# Altium schematic sheet MCU-ADDON.SchDoc
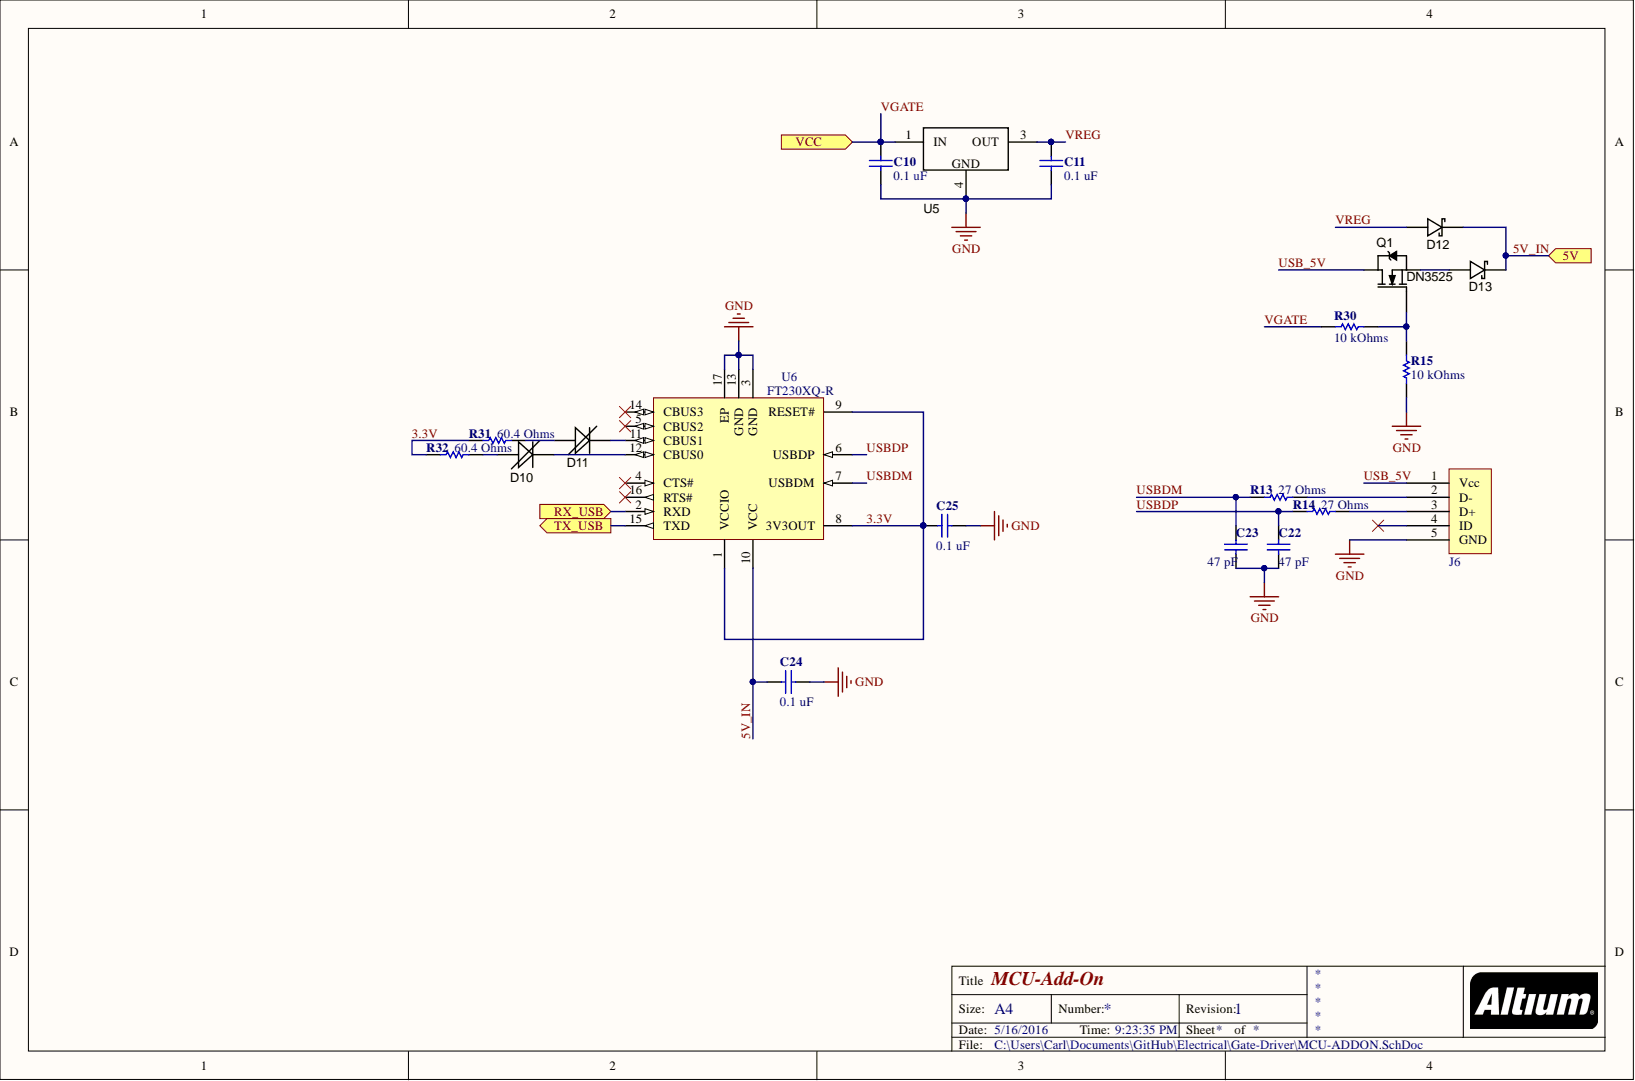
[diagram p0: MCU-ADDON.SchDoc]

## Parts
C10  0.1 uF  [CAP-0402(1005)-R]
C11  0.1 uF  [CAP-0402(1005)-R]
C22  47 pF  [CAP-0603(1608)-R]
C23  47 pF  [CAP-0603(1608)-R]
C24  0.1 uF  [CAP-0402(1005)-R]
C25  0.1 uF  [CAP-0402(1005)-R]
D10  Red  [LED-1206]  (value not drawn)
D11  Red  [LED-1206]  (value not drawn)
D12  MBR120VLSFT3G  [SOD-123FL]  (value not drawn)
D13  MBR120VLSFT3G  [SOD-123FL]  (value not drawn)
J6  USB  [USB_Connector_5P_MICRO_USB_FCI]
Q1  DN3525  [SOT89-3]
R13  27 Ohms  [R-0603(1608)-R]
R14  27 Ohms  [R-0603(1608)-R]
R15  10 kOhms  [R-0603(1608)-R]
R30  10 kOhms  [R-0603(1608)-R]
R31  60.4 Ohms  [R-0603(1608)-R]
R32  60.4 Ohms  [R-0603(1608)-R]
U5  FIXED 5V  [TO-252]  (value not drawn)
U6  FT230XQ-R  [QFN-16]

## Ports
5V, RX_USB, TX_USB, VCC

## Nets
GND: C10.2 C11.2 C22.2 C23.2 C24.2 C25.2 J6.5(GND) R15.1 U5.4 U6.3(GND) U6.13(GND) U6.17(EP)
3.3V: C25.1 R31.1 R32.1 U6.1(VCCIO) U6.8(3V3OUT) U6.9(RESET#)
5V_IN: C24.1 D12.K D13.K U6.10(VCC) ; port 5V
RX_USB: U6.2(RXD) ; port RX_USB
TX_USB: U6.15(TXD) ; port TX_USB
USB_5V: J6.1(Vcc) Q1.2
USBDM: C23.1 R13.1 U6.7(USBDM)
USBDP: C22.1 R14.1 U6.6(USBDP)
VGATE: C10.1 R30.1 U5.1 ; port VCC
VREG: C11.1 D12.A U5.3
NetD10_A: D10.A R32.2
NetD10_K: D10.K U6.12(CBUS0)
NetD11_A: D11.A R31.2
NetD11_K: D11.K U6.11(CBUS1)
NetD13_A: D13.A Q1.3
NetJ6_2: J6.2(D-) R13.2
NetJ6_3: J6.3(D+) R14.2
NetQ1_1: Q1.1 R15.2 R30.2

Not connected: J6 4(ID); U6 4(CTS#) 5(CBUS2) 14(CBUS3) 16(RTS#)

## Library data of the parts
C10 C11 C24 C25 = CAP- 0.1 uF- 16 V-CER-0402(1005): CAP CER 0.1 UF 16V 5% X7R 0402; Manufacturer Part Number: CC0402KRX7R7BB104; Brand: Yageo; Tolerance: 10 %; Type: Multilayer Ceramic Chip Capacitor; Voltage Rating DC: 16 VDC; Capacitance: 0.1 uF; Case Code - in: 0402; Case Code - mm: 1005; Category: Multilayer Ceramic Capacitors MLCC - SMD/SMT; Component Kind: Standard; ComponentLink1Description: http://www.mouser.com/ds/2/447/UPY-GPHC_X7R_6.3V-to-50V_8-12304.pdf; ComponentLink1URL: http://www.mouser.com/ds/2/447/UPY-GPHC_X7R_6.3V-to-50V_8-12304.pdf; Dielectric: X7R; Manufacturer: Yageo; Maximum Operating Temperature: + 125 C; Minimum Operating Temperature: - 55 C; Minimum Order Quantity: 1; Package / Case: 0402 (1005 metric); Packaging: Reel; Pricing 1: 1=0.100, 10=0.009, 100=0.004, 1000=0.003, 2500=0.002, 10000=0.002 (USD); Product: General Type MLCCs; Reeling: True; RoHS: RoHS Compliant By Exemption; RoHS - Mouser: E; Standard Pack Qty: 10000; Stock 1: 655262; Supplier 1: Mouser; Supplier Part Number 1: 603-CC402KRX7R7BB104; Termination Style: SMD/SMT; Library Name: CarlLibrary.SchLib
C22 C23 = CAP- 47 pF- 50 V-CER-0603(1608): CAP CER 47 pF 50V 5% C0G 0603; Manufacturer Part Number: CC0603JRNPO9BN470; Brand: Yageo; Dielectric: C0G (NP0); Type: Multilayer Ceramic Chip Capacitor; Voltage Rating DC: 50 VDC; Capacitance: 47 pF; Case Code - in: 0603; Case Code - mm: 1608; Category: Multilayer Ceramic Capacitors MLCC - SMD/SMT; Component Kind: Standard; ComponentLink1Description: http://www.mouser.com/ds/2/447/UPY-GP_NP0_16V-to-50V_8-3714.pdf; ComponentLink1URL: http://www.mouser.com/ds/2/447/UPY-GP_NP0_16V-to-50V_8-3714.pdf; Length: 1.6 mm; Manufacturer: Yageo; Maximum Operating Temperature: + 125 C; Minimum Operating Temperature: - 55 C; Minimum Order Quantity: 1; Package / Case: 0603 (1608 metric); Packaging: Reel; Pricing 1: 1=0.100, 10=0.021, 100=0.009, 1000=0.007, 4000=0.005, 48000=0.004, 100000=0.003 (USD); Product: General Type MLCCs; Reeling: True; RoHS: RoHS Compliant; RoHS - Mouser: E; Standard Pack Qty: 4000; Stock 1: 0; Supplier 1: Mouser; Supplier Part Number 1: 603-CC603JRNPO9BN470; Termination Style: SMD/SMT; Tolerance: 5 %; Width: 0.8 mm; Library Name: CarlLibrary.SchLib
D10 D11 = LED_SMD_RED_0805: LED, 0805 SMD, Red; Manufacturer Part Number: APTD3216SURCK; Brand: Kingbright; If - Forward Current: 20 mA; LED Size: 3.2 mm x 1.6 mm; Lens Color/Style: Water Clear; Luminous Intensity: 1000 mcd; Vf - Forward Voltage: 1.95 V; Viewing Angle: 50 deg; Wavelength/Color Temperature: 630 nm; Category: Standard LEDs - SMD; Component Kind: Standard; ComponentLink1Description: http://www.mouser.com/ds/2/216/APTD3216SURCK-65552.pdf; ComponentLink1URL: http://www.mouser.com/ds/2/216/APTD3216SURCK-65552.pdf; Illumination Color: Red; Manufacturer: Kingbright; Maximum Operating Temperature: + 85 C; Minimum Operating Temperature: - 40 C; Minimum Order Quantity: 1; Mounting Style: SMD/SMT; Package / Case: 1206; Packaging: Reel; Pricing 1: 1=0.270, 10=0.169, 100=0.125, 1000=0.103, 2000=0.092, 10000=0.084, 24000=0.075, 50000=0.071, 100000=0.068 (USD); Product Category: LED SMD Super Bright; Reeling: True; RoHS: RoHS Compliant; RoHS - Mouser: Y; Standard Pack Qty: 2000; Stock 1: 52291; Supplier 1: Mouser; Supplier Part Number 1: 604-APTD-3216SURCK; Library Name: CarlLibrary.SchLib
D12 D13 = DIODE_SCHOTTKY: Diode Schottky SMD 20V 1A SMD; Brand: ON Semiconductor; Configuration: Single; Ifsm - Forward Surge Current: 45 A; Ir - Reverse Current: 600 uA; Vf - Forward Voltage: 0.34 V; Category: Schottky Diodes & Rectifiers; Component Kind: Standard; ComponentLink1Description: http://www.mouser.com/ds/2/308/MBR120VLSFT1-D-107081.pdf; ComponentLink1URL: http://www.mouser.com/ds/2/308/MBR120VLSFT1-D-107081.pdf; If - Forward Current: 1 A; Manufacturer: ON Semiconductor; Manufacturer Part Number: MBR120VLSFT3G; Maximum Operating Temperature: + 125 C; Minimum Operating Temperature: - 65 C; Minimum Order Quantity: 1; Mounting Style: SMD/SMT; Package / Case: SOD-123FL; Packaging: Reel; Pricing 1: 1=0.360, 10=0.279, 100=0.151, 1000=0.114, 2500=0.098, 10000=0.091, 20000=0.084, 50000=0.081, 100000=0.076 (USD); Product: Schottky Diodes; Product Category: Diodes- Schottky; Reeling: True; RoHS: RoHS Compliant; RoHS - Mouser: Y; Series: MBR120VLSF; Standard Pack Qty: 10000; Stock 1: 22244; Supplier 1: Mouser; Supplier Part Number 1: 863-MBR120VLSFT3G; Technology: Si; Vrrm - Repetitive Reverse Voltage: 20 V; Library Name: CarlLibrary.SchLib
J6 = USB: USB_Connector_5P_MICRO_USB_FCI; Manufacturer Part Number: 10118194-0001LF; Brand: FCI; Connector Type: Micro USB Type B receptacle; Current Rating: 1.8 A; Gender: Female; Insulation Resistance: 1000 MOhms; Mounting Angle: Right; Number of Contacts: 5 Contact; Category: USB Connectors; Color: Black; Component Kind: Standard; ComponentLink1Description: http://www.mouser.com/ds/2/154/10118194AC-197217.pdf; ComponentLink1URL: http://www.mouser.com/ds/2/154/10118194AC-197217.pdf; Contact Material: Copper Alloy; Contact Plating: Gold; Flammability Rating: UL 94 V-0; Library Name: MasterLibrary.SchLib; Manufacturer: FCI; Minimum Order Quantity: 1; Operating Temperature Range: - 55 C to + 85 C; Packaging: Reel; Pricing 1: 1=0.410, 10=0.396, 100=0.278, 500=0.238, 1200=0.198, 2400=0.181, 4800=0.170, 9600=0.159, 24000=0.153 (USD); Product: Micro USB Type B Connectors; Reeling: True; RoHS: RoHS Compliant; RoHS - Mouser: Y; Standard: USB 2.0; Standard Pack Qty: 9600; Stock 1: 17001; Supplier 1: Mouser; Supplier Part Number 1: 649-10118194-0001LF; Termination Style: SMD/SMT
Q1 = DN3525N8-G: MOSFET N-Channel DEPLETION SMD; Brand: Microchip Technology; Configuration: Single; Fall Time: 25 ns; Id - Continuous Drain Current: 360 mA; Number of Channels: 1 Channel; Pd - Power Dissipation: 1.6 W; Rds On - Drain-Source Resistance: 6 Ohms; Transistor Type: 1 N-Channel; Typical Turn-On Delay Time: 20 ns; Vds - Drain-Source Breakdown Voltage: 250 V; Vgs - Gate-Source Voltage: 20 V; Category: MOSFET; Channel Mode: Depletion; Component Kind: Standard; Manufacturer: Microchip Technology; Manufacturer Part Number: DN3525N8-G; Maximum Operating Temperature: + 150 C; Minimum Operating Temperature: - 55 C; Minimum Order Quantity: 1; Mounting Style: SMD/SMT; Package / Case: SOT-89-3; Packaging: Reel; Pricing 1: 1=0.720, 10=0.650, 25=0.540, 100=0.490, 2000=0.490 (USD); Product Category: MOSFETs - Depletion Mode N-Channel; Reeling: True; Rise Time: 25 ns; RoHS: RoHS Compliant; RoHS - Mouser: Y; Standard Pack Qty: 2000; Stock 1: 34759; Supplier 1: Mouser; Supplier Part Number 1: 689-DN3525N8-G; Transistor Polarity: N-Channel; Typical Turn-Off Delay Time: 25 ns; Library Name: CarlLibrary.SchLib
R13 R14 = R-27-100 mW-0603: RES 27 OHM 100 mW  SMD; Manufacturer Part Number: RC0603JR-0727RL; Brand: Yageo; Power Rating: 100 mW; Temperature Coefficient: 100 PPM / C; Tolerance: 5 %; Voltage Rating: 75 V; Case Code - in: 0603; Case Code - mm: 1608; Category: Thick Film Resistors - SMD; Component Kind: Standard; ComponentLink1Description: http://www.mouser.com/ds/2/447/RC0603-272842.pdf; ComponentLink1URL: http://www.mouser.com/ds/2/447/RC0603-272842.pdf; Height: 0.45 mm; Length: 1.6 mm; Manufacturer: Yageo; Minimum Order Quantity: 1; Operating Temperature Range: - 55 C to + 155 C; Package / Case: 0603 (1608 metric); Packaging: Reel; Pricing 1: 1=0.100, 10=0.008, 100=0.003, 1000=0.002, 5000=0.002, 50000=0.001 (USD); Product: Thick Film Resistors General Purpose; Product Category: 0603 SMD Chip Resistors; Reeling: True; Resistance: 27 Ohms; RoHS: RoHS Compliant; RoHS - Mouser: Y; Series: RC; Standard Pack Qty: 5000; Stock 1: 21649; Supplier 1: Mouser; Supplier Part Number 1: 603-RC0603JR-0727RL; Termination Style: SMD/SMT; Type: General Purpose Chip Resistor Surface Mount; Width: 0.8 mm; Library Name: CarlLibrary.SchLib
R15 R30 = R-10k-100mW-0603: RES 10k OHM 1/10W 0603 SMD; Manufacturer Part Number: RC0603FR-0710KL; Brand: Yageo; Power Rating: 100 mW; Temperature Coefficient: 100 PPM / C; Tolerance: 1 %; Voltage Rating: 75 V; Case Code - in: 0603; Case Code - mm: 1608; Category: Thick Film Resistors - SMD; Component Kind: Standard; ComponentLink1Description: http://www.mouser.com/ds/2/447/RC0603-272842.pdf; ComponentLink1URL: http://www.mouser.com/ds/2/447/RC0603-272842.pdf; Height: 0.45 mm; Length: 1.6 mm; Manufacturer: Yageo; Minimum Order Quantity: 1; Operating Temperature Range: - 55 C to + 155 C; Package / Case: 0603 (1608 metric); Packaging: Reel; Pricing 1: 1=0.100, 10=0.010, 100=0.003, 1000=0.002, 5000=0.002, 10000=0.001 (USD); Product: Thick Film Resistors General Purpose; Product Category: 0603 SMD Chip Resistors; Reeling: True; Resistance: 10 kOhms; RoHS: RoHS Compliant; RoHS - Mouser: Y; Series: RC; Standard Pack Qty: 5000; Stock 1: 240719; Supplier 1: Mouser; Supplier Part Number 1: 603-RC0603FR-0710KL; Termination Style: SMD/SMT; Type: General Purpose Chip Resistor Surface Mount; Width: 0.8 mm; Library Name: CarlLibrary.SchLib
R31 R32 = R-60.4-100mW-0805: RES 60.4 OHM 100 mW  SMD; Manufacturer Part Number: RC0603FR-0760R4L; Brand: Yageo; Power Rating: 100 mW; Temperature Coefficient: 100 PPM / C; Tolerance: 1 %; Voltage Rating: 75 V; Case Code - in: 0603; Case Code - mm: 1608; Category: Thick Film Resistors - SMD; Component Kind: Standard; ComponentLink1Description: http://www.mouser.com/ds/2/447/RC0603-272842.pdf; ComponentLink1URL: http://www.mouser.com/ds/2/447/RC0603-272842.pdf; Height: 0.45 mm; Length: 1.6 mm; Manufacturer: Yageo; Minimum Order Quantity: 1; Operating Temperature Range: - 55 C to + 155 C; Package / Case: 0603 (1608 metric); Packaging: Reel; Pricing 1: 1=0.100, 10=0.010, 100=0.003, 1000=0.002, 5000=0.002, 10000=0.001 (USD); Product: Thick Film Resistors General Purpose; Reeling: True; Resistance: 60.4 Ohms; RoHS: RoHS Compliant; RoHS - Mouser: Y; Series: RC; Stock 1: 5823; Supplier 1: Mouser; Supplier Part Number 1: 603-RC0603FR-0760R4L; Termination Style: SMD/SMT; Type: General Purpose Chip Resistor Surface Mount; Width: 0.8 mm; Library Name: CarlLibrary.SchLib
U5 = MC78M05CDTRKG: Voltage Regulator IC, DPAK SMD, MC78M05CDTRKG; Brand: ON Semiconductor; Input Voltage MAX: 35 V; Input Voltage MIN: 7 V; Line Regulation: 50 mV; Load Regulation: 100 mV; Number of Outputs: 1; Output Current: 500 mA; Polarity: Positive; PSRR / Ripple Rejection - Typ: 80 dB; Category: Linear Voltage Regulators; Component Kind: Standard; ComponentLink1Description: http://www.mouser.com/ds/2/308/MC78M00-D-88441.pdf; ComponentLink1URL: http://www.mouser.com/ds/2/308/MC78M00-D-88441.pdf; Manufacturer: ON Semiconductor; Manufacturer Part Number: MC78M05CDTRKG; Maximum Operating Temperature: + 125 C; Minimum Operating Temperature: 0 C; Minimum Order Quantity: 1; Mounting Style: SMD/SMT; Output Type: Fixed; Output Voltage: 5 V; Package / Case: TO-252-3 (DPAK); Packaging: Reel; Pricing 1: 1=0.470, 10=0.353, 100=0.222, 1000=0.166, 2500=0.142, 10000=0.132, 25000=0.125, 50000=0.119, 100000=0.116 (USD); Product Category: Linear Regulators- General Purpose; Reeling: True; RoHS: RoHS Compliant; RoHS - Mouser: Y; Series: MC78M05; Standard Pack Qty: 2500; Stock 1: 26245; Supplier 1: Mouser; Supplier Part Number 1: 863-MC78M05CDTRKG; Library Name: CarlLibrary.SchLib
U6 = FT230XQ-R: USB to Basic UART Interface Chip, UHCI/OHCI/EHCI Compatible, USB 2.0 Compatible, -40 to +85 degC, 16-Pin QFN, Pb-Free, Tape and Reel; Brand: FTDI; Data Rate: 480 Mb/s, 12 Mb/s; Interface Type: UART, USB; Operating Supply Current: 6.5 mA to 8.3 mA; Operating Supply Voltage: 2.97 V to 5.5 V; PackagingOptionsScrubbed: FT230XQT; Speed: High-Speed; Category: USB Interface IC; Component Kind: Standard; ComponentLink1Description: http://www.mouser.com/ds/2/163/DS_FT230X-5395.pdf; ComponentLink1URL: http://www.mouser.com/ds/2/163/DS_FT230X-5395.pdf; Manufacturer: FTDI; Manufacturer Part Number: FT230XQ-R; Maximum Operating Temperature: + 85 C; Minimum Order Quantity: 1; Mounting Style: SMD/SMT; Package / Case: QFN-16; Packaging: Reel; Pricing 1: 1=2.04, 25=1.97, 100=1.90, 250=1.71, 500=1.54, 1000=1.46, 2500=1.38, 5000=1.38 (USD); Product: USB Bridge Controllers; Reeling: True; RoHS: RoHS Compliant; RoHS - Mouser: Y; Series: FT230; Standard: USB 2.0; Standard Pack Qty: 5000; Stock 1: 69254; Supplier 1: Mouser; Supplier Part Number 1: 895-FT230XQ-R; Tradename: X-Chip; Type: Bridge, USB to UART; Library Name: CarlLibrary.SchLib
